# Altium schematic sheet power.SchDoc
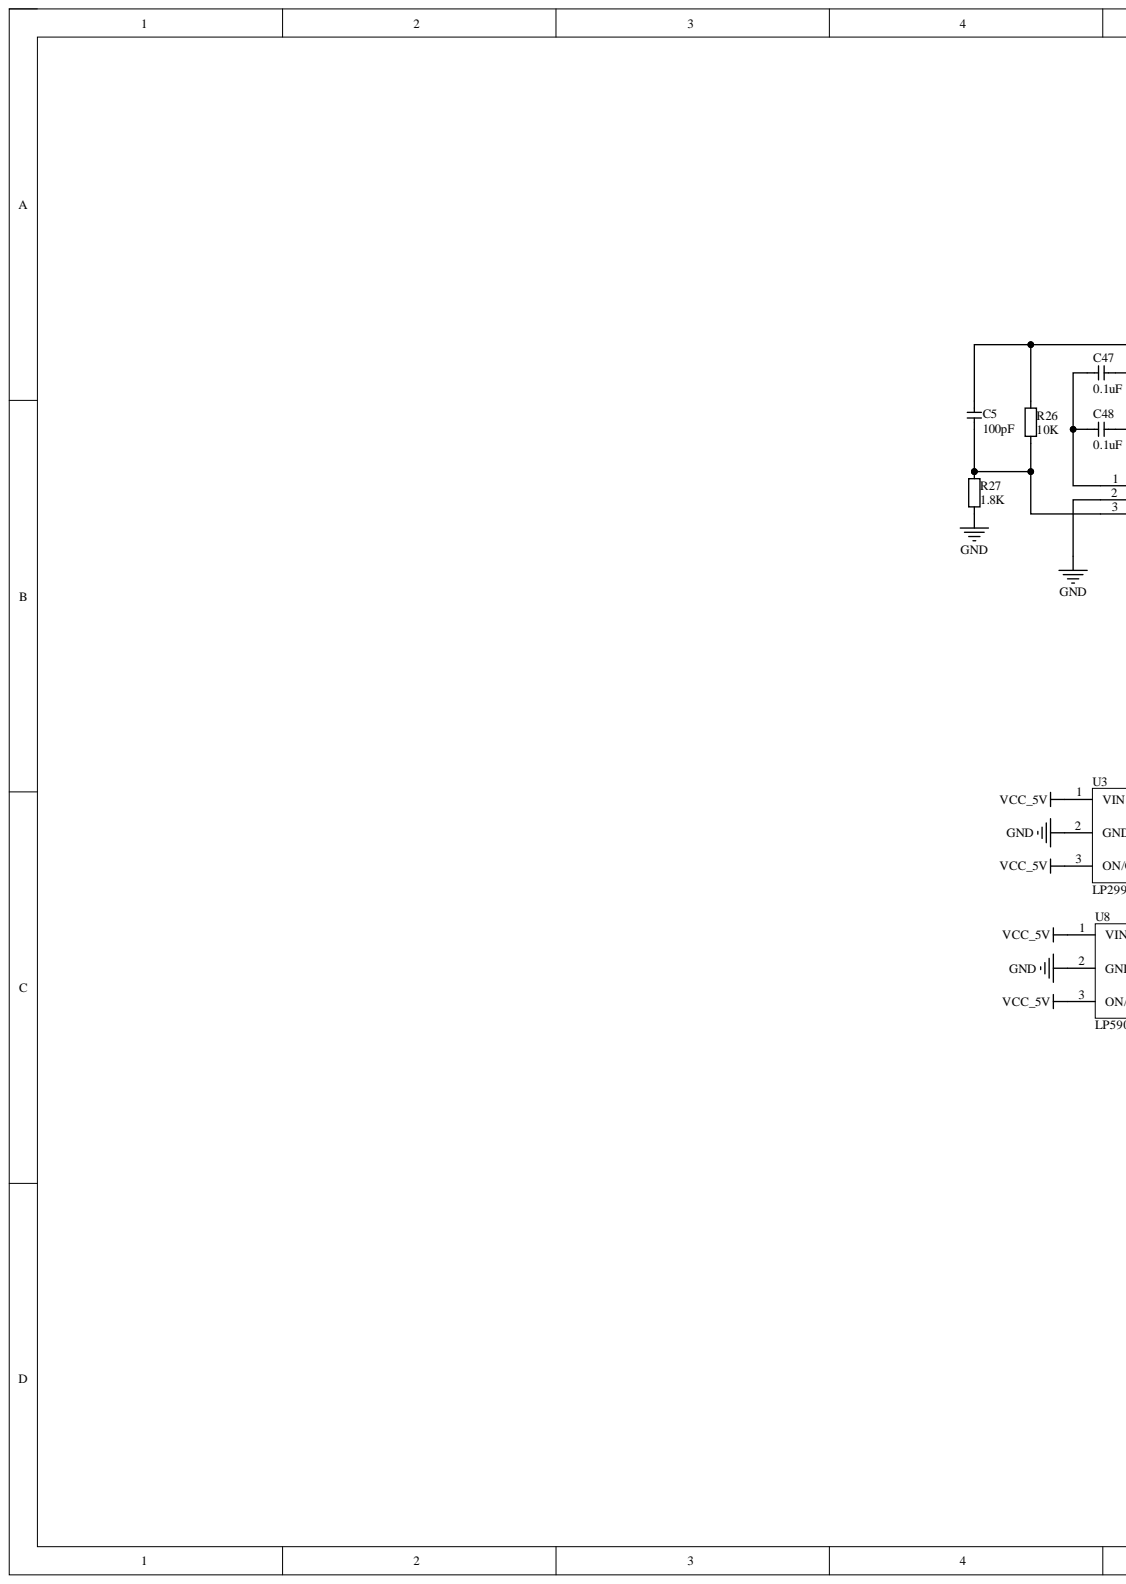
[diagram p0: power.SchDoc, left]
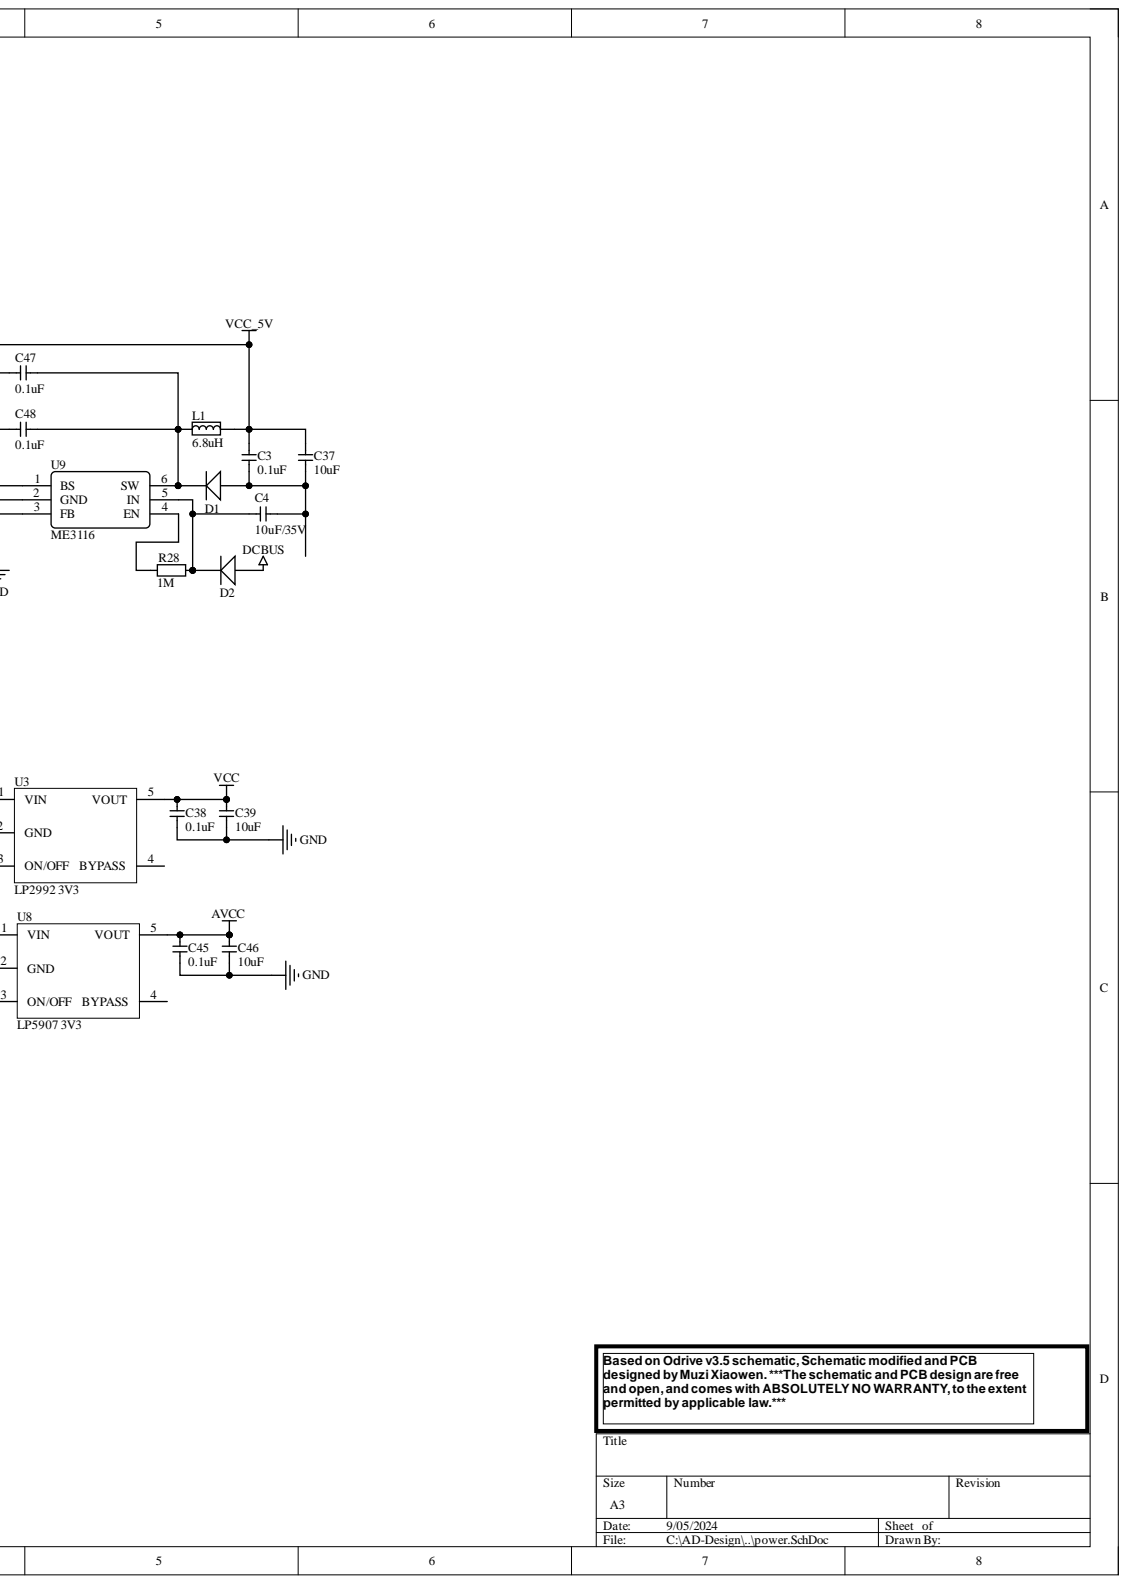
[diagram p1: power.SchDoc, right]

## Parts
C3  0.1uF  [CAP_0402]
C4  10uF/35V  [C0603]
C5  100pF  [CAP_0402]
C37  10uF  [CAP_0402]
C38  0.1uF  [CAP_0402]
C39  10uF  [CAP_0402]
C45  0.1uF  [CAP_0402]
C46  10uF  [CAP_0402]
C47  0.1uF  [CAP_0402]
C48  0.1uF  [CAP_0402]
D1  二极管-5819W  [SOD-323]  (value not drawn)
D2  二极管-5819W  [SOD-323]  (value not drawn)
L1  6.8uH  [IND-201612]
R26  10K  [RES_0402]
R27  1.8K  [RES_0402]
R28  1M  [RES_0402]
U3  LP2992 3V3  [SOT23-5]
U8  LP5907 3V3  [SOT23-5]
U9  ME3116  [SOT23-6]

## Nets
AVCC: C45.2 C46.2 U8.5(VOUT)
DCBUS: D2.1
GND: C38.1 C39.1 C45.1 C46.1 R27.1 U3.2(GND) U8.2(GND) U9.2(GND)
VCC: C38.2 C39.2 U3.5(VOUT)
VCC_5V: C3.2 C5.1 C37.2 L1.2 R26.2 U3.1(VIN) U3.3(ON/OFF) U8.1(VIN) U8.3(ON/OFF)
NetC3_1: C3.1 C4.1 C37.1 D1.1
NetC4_2: C4.2 D2.2 R28.1 U9.5(IN)
NetC5_2: C5.2 R26.1 R27.2 U9.3(FB)
NetC47_1: C47.1 C48.1 D1.2 L1.1 U9.6(SW)
NetC47_2: C47.2 C48.2 U9.1(BS)
NetR28_2: R28.2 U9.4(EN)

Not connected: U3 4(BYPASS); U8 4(BYPASS)

## Library data of the parts
C3 C5 C37-C39 C45-C48 = 电容-0402: Capacitor; Value: 0.1u; Component Kind: Standard; Library Name: MyPcbLib.IntLib
C4 = 电容-0402: Capacitor; Value: 22uF 35V; Component Kind: Standard; Library Name: MyPcbLib.IntLib
D1 D2 = 二极管-5819W: 40V; Component Kind: Standard; Library Name: MyPcbLib.IntLib
L1 = 电感-201612: Component Kind: Standard; Library Name: MyPcbLib.IntLib
R26-R28 = 电阻-0402: Resistor; Value: 22R; Component Kind: Standard; Library Name: MyPcbLib.IntLib
U3 U8 = LP2992: LDO; Component Kind: Standard; Library Name: MyPcbLib.IntLib
U9 = ME3116: DC-DC; Component Kind: Standard; Library Name: MyPcbLib.IntLib
